# Altium schematic sheet Sheet3.SchDoc(Sheet3)
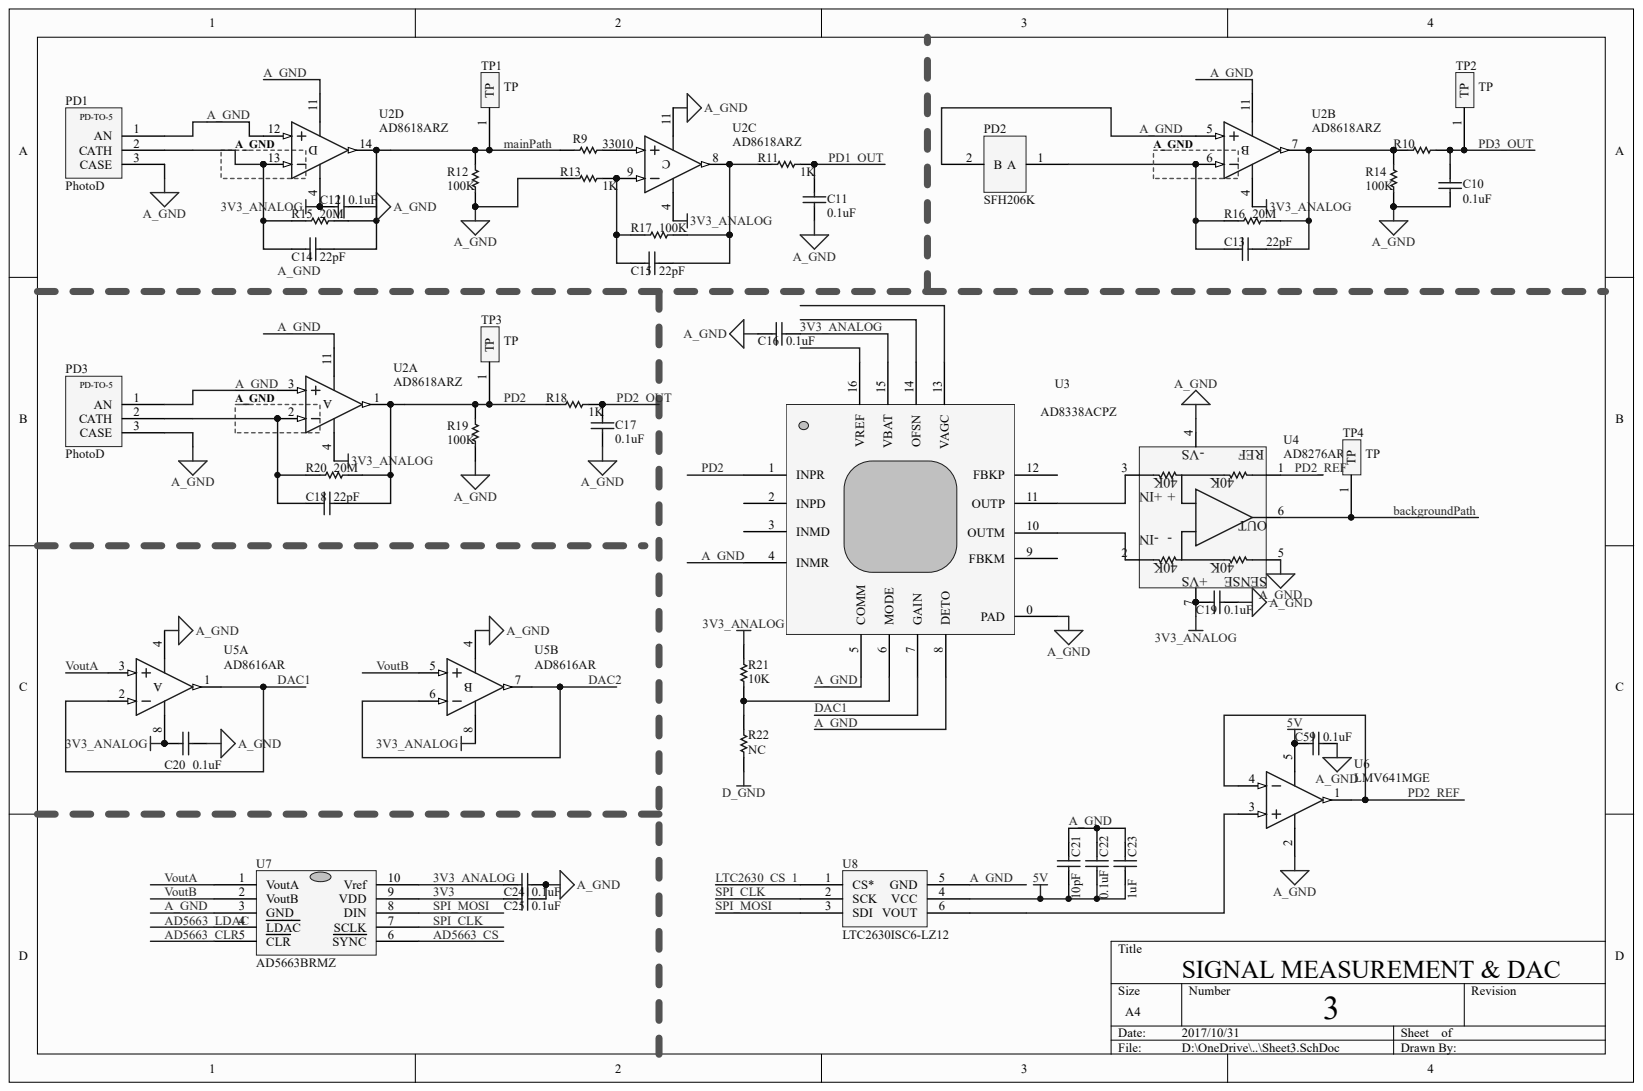
[diagram p0: Sheet3.SchDoc]

## Parts
C10  value 0.1uF  (hidden comment: Cap)
C11  value 0.1uF  (hidden comment: Cap)
C12  value 0.1uF  (hidden comment: Cap)
C13  value 22pF  (hidden comment: Cap)
C14  value 22pF  (hidden comment: Cap)
C15  value 22pF  (hidden comment: Cap)
C16  value 0.1uF  (hidden comment: Cap)
C17  value 0.1uF  (hidden comment: Cap)
C18  value 22pF  (hidden comment: Cap)
C19  value 0.1uF  (hidden comment: Cap)
C20  value 0.1uF  (hidden comment: Cap)
C21  value 10pF  (hidden comment: Cap)
C22  value 0.1uF  (hidden comment: Cap)
C23  value 1uF  (hidden comment: Cap)
C24  value 0.1uF  (hidden comment: Cap)
C25  value 0.1uF  (hidden comment: Cap)
C59  value 0.1uF  (hidden comment: Cap)
PD1  PhotoD
PD2  SFH206K
PD3  PhotoD
R9  value 330  (hidden comment: Res1)
R10  Res1  (value not drawn)
R11  value 1K  (hidden comment: Res1)
R12  value 100K  (hidden comment: Res1)
R13  value 1K  (hidden comment: Res1)
R14  value 100K  (hidden comment: Res1)
R15  value 20M  (hidden comment: Res1)
R16  value 20M  (hidden comment: Res1)
R17  value 100K  (hidden comment: Res1)
R18  value 1K  (hidden comment: Res1)
R19  value 100K  (hidden comment: Res1)
R20  value 20M  (hidden comment: Res1)
R21  value 10K  (hidden comment: Res1)
R22  value NC  (hidden comment: Res1)
TP1  TP
TP2  TP
TP3  TP
TP4  TP
U2  AD8618ARZ  (parts A,B,C,D)
U3  AD8338ACPZ
U4  AD8276ARZ
U5  AD8616AR  (parts A,B)
U6  LMV641MGE
U7  AD5663BRMZ
U8  LTC2630ISC6-LZ12

## Nets
5V: C21.2 C22.2 C23.2 C59.1 U6.5 U8.4(VCC)
D_GND: R22.1
3V3: C25.2 U7.9(VDD)
3V3_ANALOG: C12.1 C16.1 C19.2 C20.1 C24.2 R21.2 U2.4 U3.15(VBAT) U4.7 U5.8 U7.10(Vref)
A_GND: C10.1 C11.1 C12.2 C16.2 C17.1 C19.1 C20.2 C21.1 C22.1 C23.1 C24.1 C25.1 C59.2 PD1.1 PD1.3 PD2.2 PD3.1 PD3.3 R12.2 R13.1 R14.2 R19.2 U2.3 U2.5 U2.11 U2.12 U3.0(PAD) U3.4(INMR) U3.5(COMM) U3.8(DETO) U4.4 U4.5 U5.4 U6.2 U7.3(GND) U8.5(GND)
AD5663_CLR: U7.5(CLR)
AD5663_CS: U7.6(SYNC)
AD5663_LDAC: U7.4(LDAC)
BACKGROUNDPATH: TP4.1 U4.6
DAC1: U3.7(GAIN) U5.1 U5.2
DAC2: U5.6 U5.7
LTC2630_CS_1: U8.1(CS*)
MAINPATH: C14.1 R9.1 R12.1 R15.1 TP1.1 U2.14
PD1_OUT: C11.2 R11.2
PD2: C18.1 R18.1 R19.1 R20.1 TP3.1 U2.1 U3.1(INPR)
PD2_OUT: C17.2 R18.2
PD2_REF: U4.1 U6.1 U6.4
PD3_OUT: C10.2 R10.1 TP2.1
SPI_CLK: U7.7(SCLK) U8.2(SCK)
SPI_MOSI: U7.8(DIN) U8.3(SDI)
VOUTA: U5.3 U7.1(VoutA)
VOUTB: U5.5 U7.2(VoutB)
NetC13_1: C13.1 R10.2 R14.1 R16.1 U2.7
NetC13_2: C13.2 PD2.1 R16.2 U2.6
NetC14_2: C14.2 PD1.2 R15.2 U2.13
NetC15_1: C15.1 R11.1 R17.1 U2.8
NetC15_2: C15.2 R13.2 R17.2 U2.9
NetC18_2: C18.2 PD3.2 R20.2 U2.2
NetR9_2: R9.2 U2.10
NetR21_1: R21.1 R22.2 U3.6(MODE)
NetU3_10: U3.10(OUTM) U4.2(40K)
NetU3_11: U3.11(OUTP) U4.3
NetU6_3: U6.3 U8.6(VOUT)

Not connected: U3 2(INPD) 3(INMD) 9(FBKM) 12(FBKP) 13(VAGC) 14(OFSN) 16(VREF)

## Library data of the parts
C10-C25 C59 PD1-PD3 R9-R22 TP1-TP4 U2-U8 = (no symbol name)
  C10-C25 C59: Published: 8-Jun-2000; LatestRevisionDate: 17-Jul-2002; LatestRevisionNote: Re-released for DXP Platform.; PackageReference: RAD-0.3
  R9-R22: Published: 8-Jun-2000; LatestRevisionDate: 17-Jul-2002; LatestRevisionNote: Re-released for DXP Platform.; PackageReference: AXIAL-0.3
  U2: Published: 8-Jun-2000; LatestRevisionDate: 04-Mar-2005; LatestRevisionNote: Stylized 3D Model Added.; DatasheetVersion: REV. B; PackageVersion: revB; PackageReference: Q-14; PackageDescription: DIP; 14 Leads; Row Spacing 7.62 mm; Pitch 2.54 mm; ComponentLink1Description: Manufacturer Link; ComponentLink1URL: http://www.analog.com/en/prod/0,,759_786_AD713%2C00.html; ComponentLink2Description: Datasheet; ComponentLink2URL: http://www.analog.com/UploadedFiles/Data_Sheets/70859332AD713_c.pdf
  U5: Published: 8-Jun-2000; LatestRevisionDate: 13-Apr-2006; LatestRevisionNote: IPC-7351 Footprint Added.; DatasheetVersion: REV. B 1998; PackageVersion: revA; PackageReference: SO-8; PackageDescription: 8-Pin Small Outline Integrated Circuit 1.27 mm Pitch; Code_JEDEC: MS-012-AA; Code_IPC: SOIC127P600-8; ComponentLink1Description: Manufacturer Link; ComponentLink1URL: http://www.analog.com/en/prod/0,,759_786_AD812%2C00.html; ComponentLink2Description: Datasheet; ComponentLink2URL: http://www.analog.com/UploadedFiles/Data_Sheets/200001408AD812_b.pdf
  C10-C25 C59 R9-R22 U2 U5: Publisher: Altium Limited
